# Altium schematic sheet Full-H Bridge_C.SchDoc("Full-H Bridge_C")
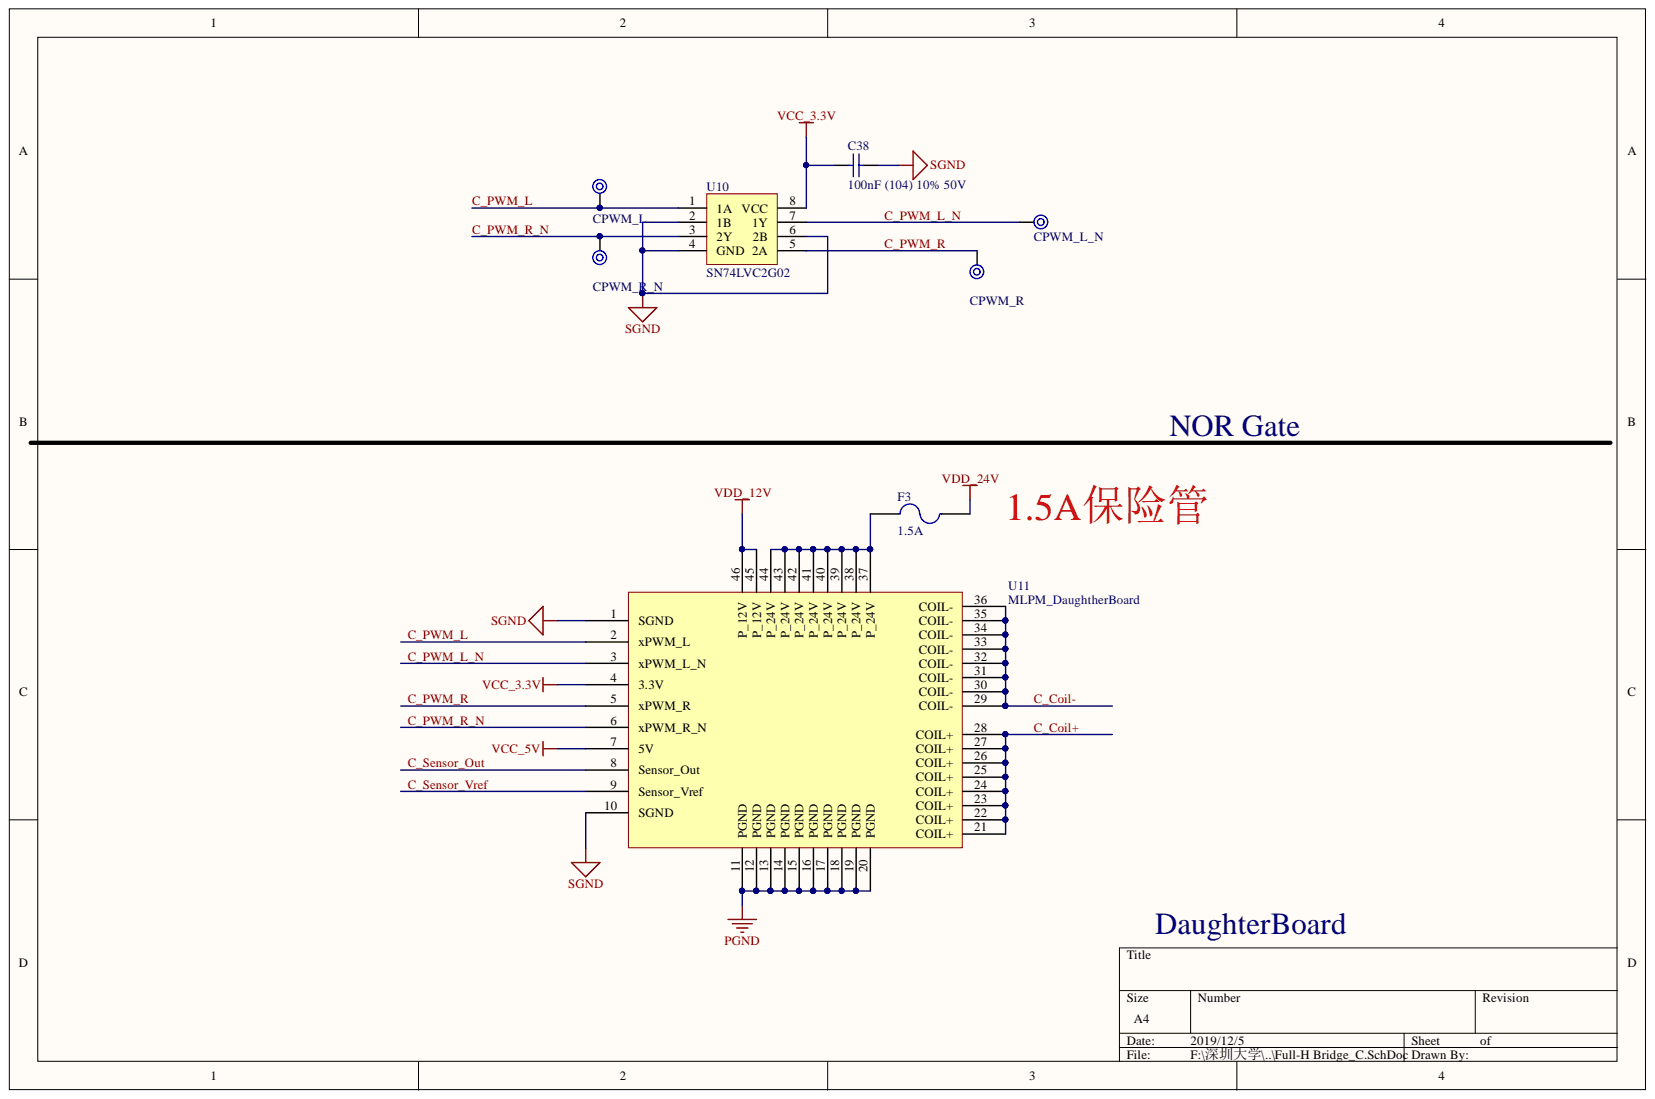
[diagram p0: Full-H Bridge_C.SchDoc]

## Parts
C38  100nF (104) 10% 50V  [0603_C]
CPWM_L  TP_Surface  [TEST_POINT_ME]  (value not drawn)
CPWM_L_N  TP_Surface  [TEST_POINT_ME]  (value not drawn)
CPWM_R  TP_Surface  [TEST_POINT_ME]  (value not drawn)
CPWM_R_N  TP_Surface  [TEST_POINT_ME]  (value not drawn)
F3  value 1.5A  [1812]  (hidden comment: Fuse)
U10  SN74LVC2G02  [SN74LVC2G02]
U11  MLPM_DaughtherBoard  [MLPM_DaughtherBoard]

## Nets
PGND: U11.11(PGND) U11.12(PGND) U11.13(PGND) U11.14(PGND) U11.15(PGND) U11.16(PGND) U11.17(PGND) U11.18(PGND) U11.19(PGND) U11.20(PGND)
SGND: C38.2 U10.2(1B) U10.4(GND) U10.6(2B) U11.1(SGND) U11.10(SGND)
VCC_3.3V: C38.1 U10.8(VCC) U11.4(3.3V)
VCC_5V: U11.7(5V)
VDD_12V: U11.45(P_12V) U11.46(P_12V)
VDD_24V: F3.2
C_Coil+: U11.21(COIL+) U11.22(COIL+) U11.23(COIL+) U11.24(COIL+) U11.25(COIL+) U11.26(COIL+) U11.27(COIL+) U11.28(COIL+)
C_Coil-: U11.29(COIL-) U11.30(COIL-) U11.31(COIL-) U11.32(COIL-) U11.33(COIL-) U11.34(COIL-) U11.35(COIL-) U11.36(COIL-)
C_PWM_L: CPWM_L.1 U10.1(1A) U11.2(xPWM_L)
C_PWM_L_N: CPWM_L_N.1 U10.7(1Y) U11.3(xPWM_L_N)
C_PWM_R: CPWM_R.1 U10.5(2A) U11.5(xPWM_R)
C_PWM_R_N: CPWM_R_N.1 U10.3(2Y) U11.6(xPWM_R_N)
C_Sensor_Out: U11.8(Sensor_Out)
C_Sensor_Vref: U11.9(Sensor_Vref)
NetF3_1: F3.1 U11.37(P_24V) U11.38(P_24V) U11.39(P_24V) U11.40(P_24V) U11.41(P_24V) U11.42(P_24V) U11.43(P_24V) U11.44(P_24V)

## Library data of the parts
C38 = 0603 100nF (104) 10% 50V: 贴片电容; SuppliersPartNumber: C14663; Supplier: LC; Package: 0603; Library Name: JLC Library.IntLib; ComponentLink1URL: http://www.szlcsc.com/product/details_15331.html; ComponentLink1Description: 供应商链接; Component Kind: Standard
F3 = Fuse 2: 保险丝; Published: 8-Jun-2000; PackageReference: PIN-W2/E2.8; LatestRevisionNote: Re-released for DXP Platform.; LatestRevisionDate: 17-Jul-2002; Component Kind: Standard; Publisher: Altium Limited; Library Name: 接插件集成库.IntLib
U10 = SN74LVC2G02: 2通道高速与非门; Component Kind: Standard; Library Name: PSRM_Sensorless_V2.0模块.IntLib
U11 = MLPM_Driver_DaugtherBoard: 磁悬浮平面电机电流驱动器子板; Component Kind: Standard; Library Name: MPLM_Driver_V10A1.IntLib
CPWM_L CPWM_L_N CPWM_R CPWM_R_N = TP_Surface: Component Kind: Standard; Library Name: PSRM_Sensorless_V2.0模块.IntLib
